# Altium schematic sheet Laser.SchDoc
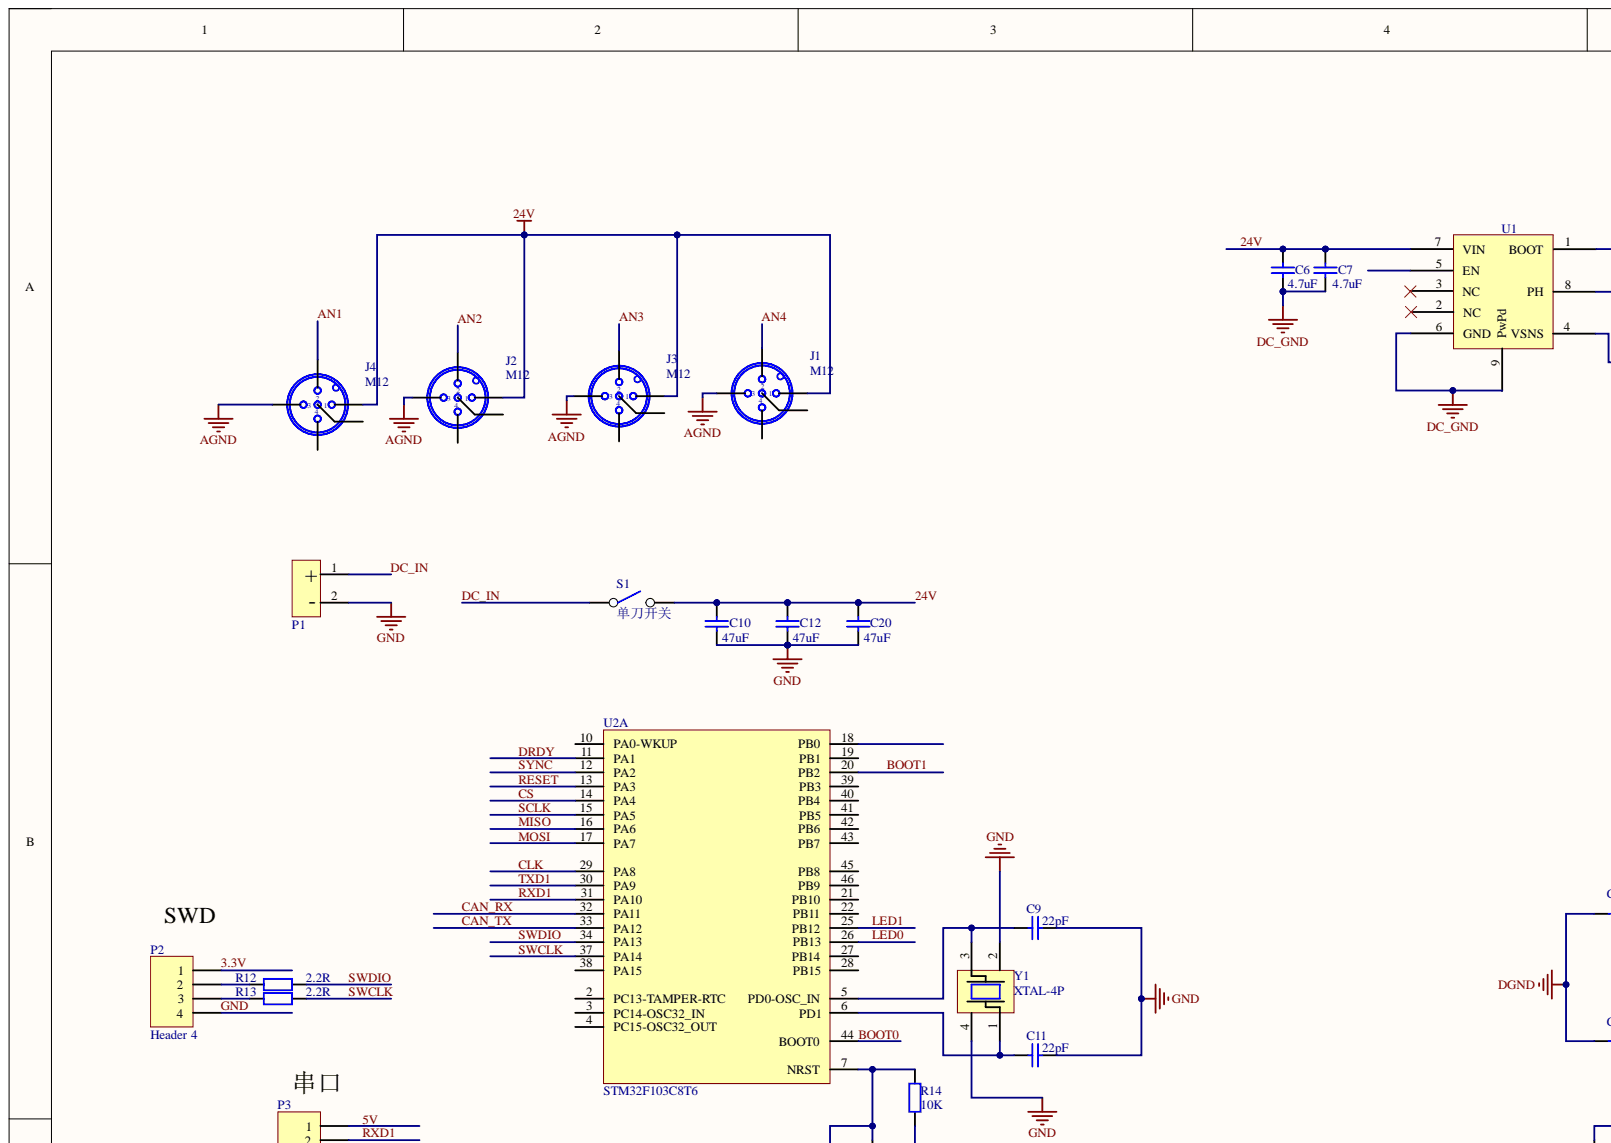
[diagram p0: Laser.SchDoc, top left]
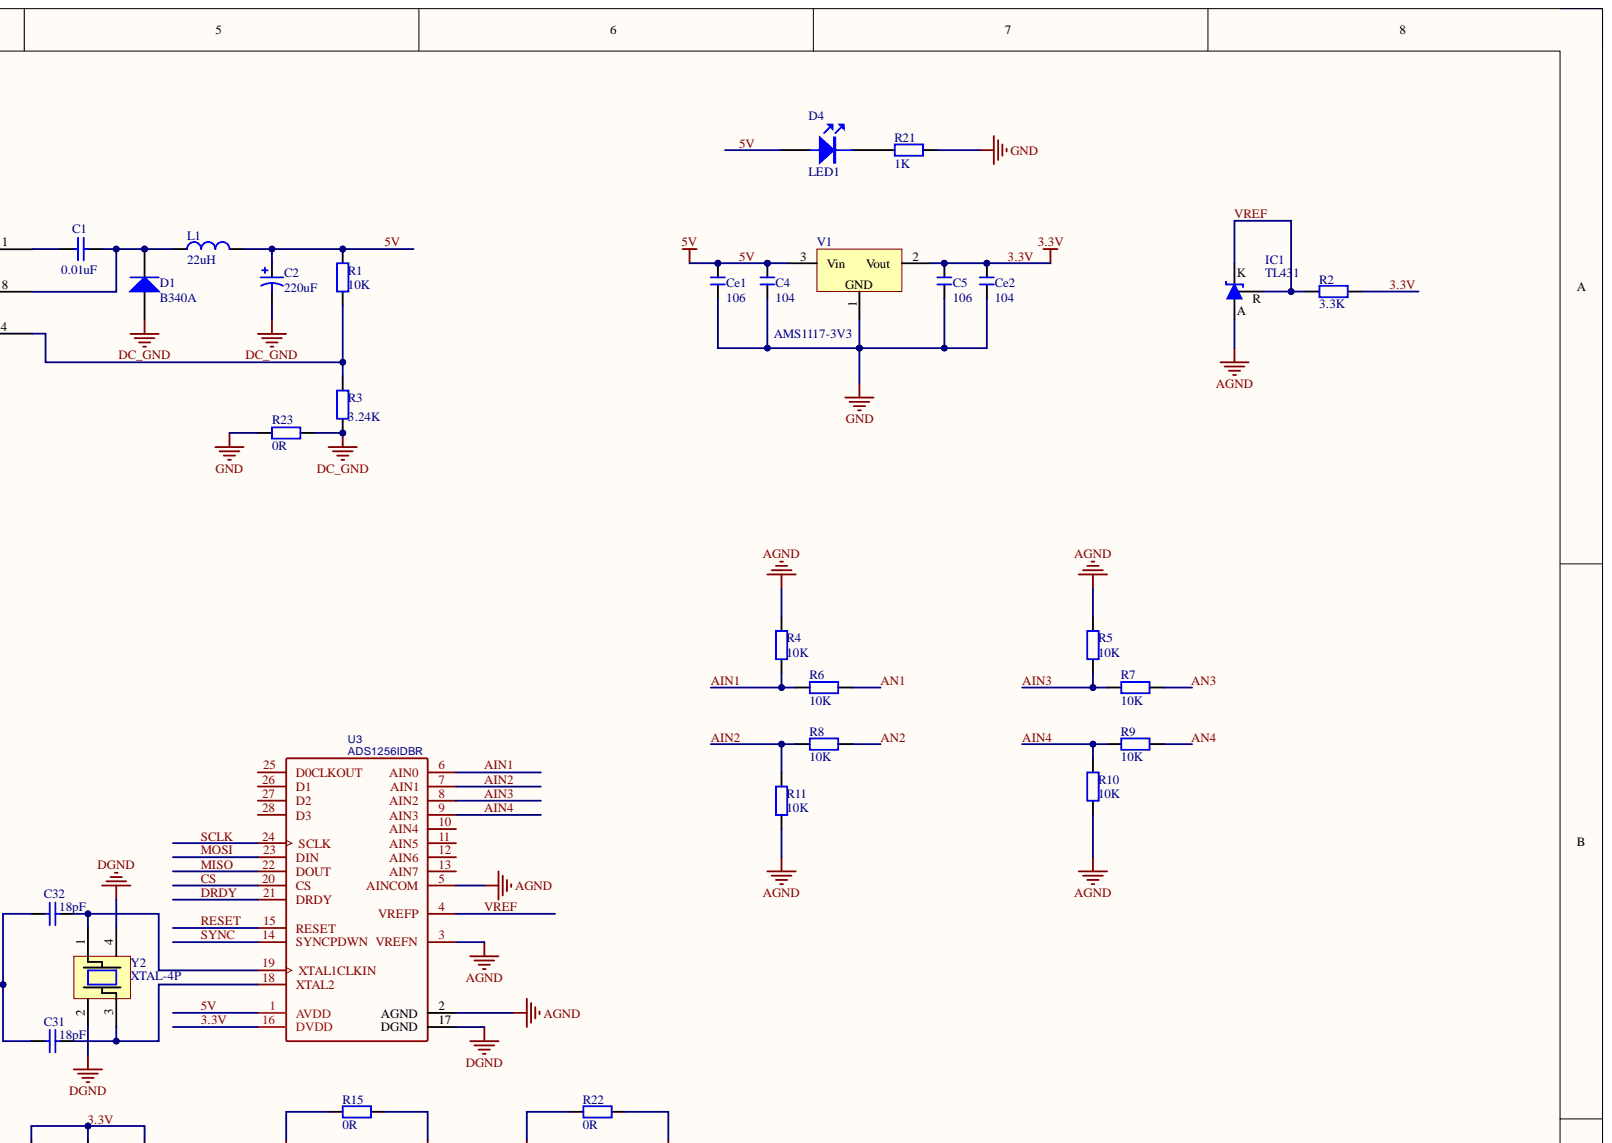
[diagram p1: Laser.SchDoc, top right]
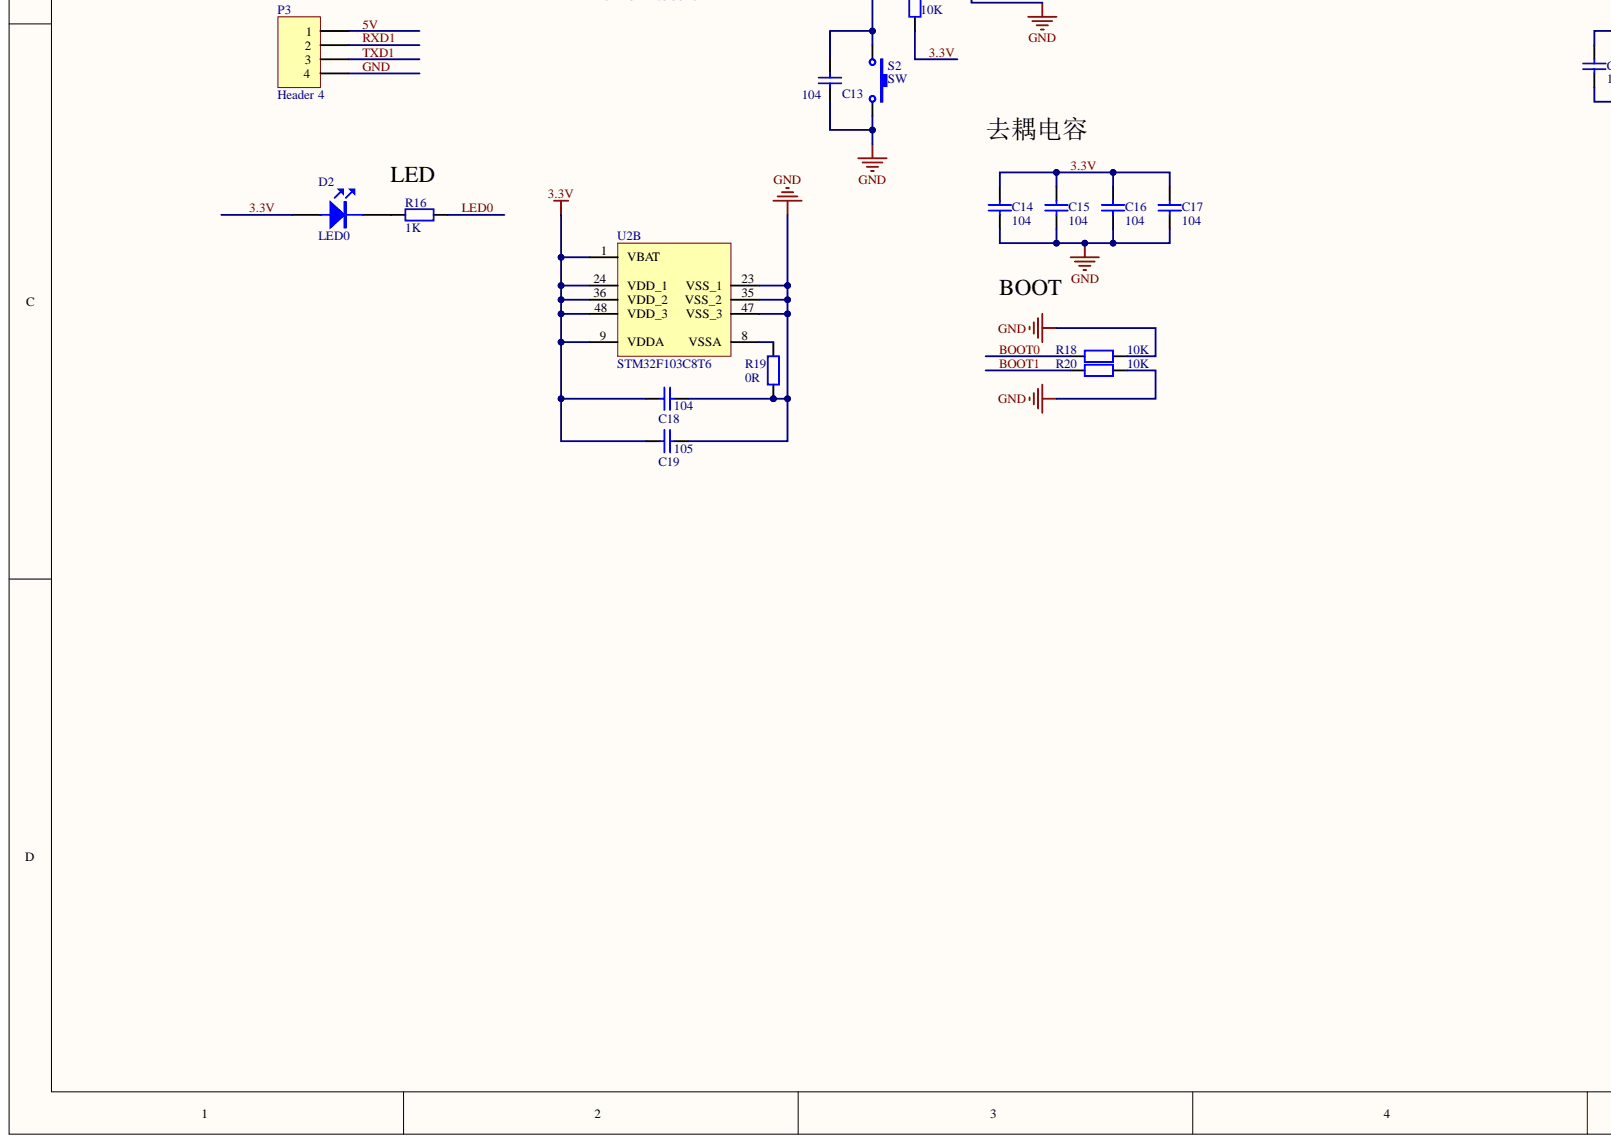
[diagram p2: Laser.SchDoc, bottom left]
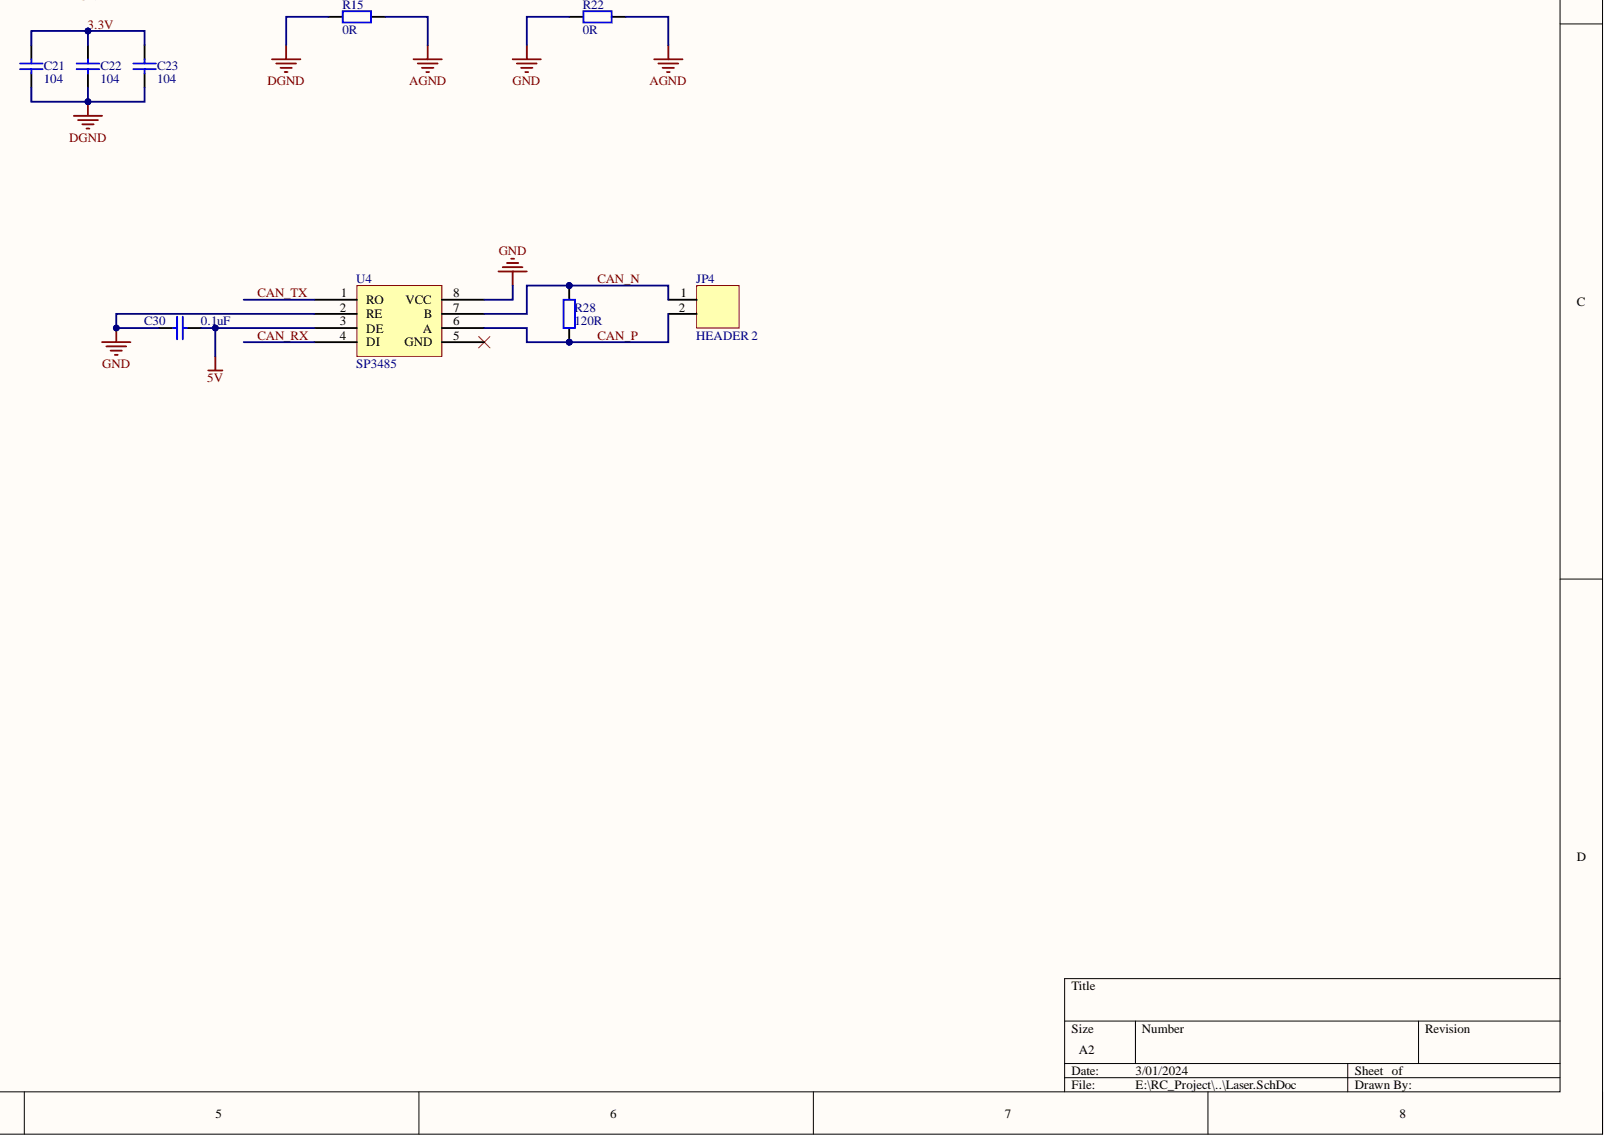
[diagram p3: Laser.SchDoc, bottom right]

## Parts
C1  Parameter 1: 0.01uF  [0805c]  (hidden comment: CAP-SMD)
C2  value 220uF  [CAP_VT 10*10.5]  (hidden comment: Cap Pol3)
C4  104  [0805c]
C5  106  [0805c]
C6  Parameter 1: 4.7uF  [0805c]  (hidden comment: CAP-SMD)
C7  Parameter 1: 4.7uF  [0805c]  (hidden comment: CAP-SMD)
C9  22pF  [0805c]
C10  47uF  [C1206]
C11  22pF  [0805c]
C12  47uF  [C1206]
C13  104  [0805c]
C14  104  [0805c]
C15  104  [0805c]
C16  104  [0805c]
C17  104  [0805c]
C18  104  [0805c]
C19  105  [0805c]
C20  47uF  [C1206]
C21  104  [0805c]
C22  104  [0805c]
C23  104  [0805c]
C30  0.1uF  [0805c]
C31  18pF  [0805c]
C32  18pF  [0805c]
Ce1  106  [0805c]
Ce2  104  [0805c]
D1  Type: B340A  [DIODE-SMA]  (hidden comment: Diode)
D2  LED0  [0805D]
D4  LED1  [0805D]
IC1  TL431  [SOT23-3N]
J1  M12  [M12]
J2  M12  [M12]
J3  M12  [M12]
J4  M12  [M12]
JP4  HEADER 2  [GH1.25-2P]
L1  value 22uH  [SMD-7/电感]  (hidden comment: Inductor)
P1  XT30PW-M  [XT30PW-M]  (value not drawn)
P2  Header 4  [GH1.25-4P]
P3  Header 4  [GH1.25-4P]
R1  Parameter 1: 10K  [R 0805]  (hidden comment: RES-SMD)
R2  Parameter 1: 3.3K  [R 0805]  (hidden comment: RES-SMD)
R3  Parameter 1: 3.24K  [R 0805]  (hidden comment: RES-SMD)
R4  10K  [0805R]
R5  10K  [0805R]
R6  10K  [0805R]
R7  10K  [0805R]
R8  10K  [0805R]
R9  10K  [0805R]
R10  10K  [0805R]
R11  10K  [0805R]
R12  value 2.2R  [0805R]  (hidden comment: Res1)
R13  value 2.2R  [0805R]  (hidden comment: Res1)
R14  value 10K  [0805R]  (hidden comment: Res1)
R15  0R  [0805R]
R16  value 1K  [0805R]  (hidden comment: Res1)
R18  value 10K  [0805R]  (hidden comment: Res1)
R19  value 0R  [0805R]  (hidden comment: Res1)
R20  value 10K  [0805R]  (hidden comment: Res1)
R21  value 1K  [0805R]  (hidden comment: Res1)
R22  0R  [0805R]
R23  Parameter 1: 0R  [R 0805]  (hidden comment: RES-SMD)
R28  value 120R  [0805R]  (hidden comment: 0R)
S1  单刀开关  [SWITCH_A2]
S2  SW  [SW_PB2_A1]
U1  TPS5430  [SOIC-8_L5.0-W4.0-P1.27-LS6.0-BL-EP2.0]  (value not drawn)
U2  STM32F103C8T6  [LQFP48_N]  (parts A,B)
U3  ADS1256IDBR  [SSOP-28]
U4  SP3485  [SOP-8]
V1  AMS1117-3V3  [SOT223_L]
Y1  XTAL-4P  [OSC-SMD_4P-L5.0-W3.2-BL]
Y2  XTAL-4P  [OSC-SMD_4P-L5.0-W3.2-BL]

## Nets
AGND: IC1.3 J1.3 J2.3 J3.3 J4.3 R4.1 R5.1 R10.2 R11.2 R15.1 R22.1 U3.2(AGND) U3.3(VREFN) U3.5(AINCOM)
DC_GND: C2.2 C6.1 C7.1 D1.1 R3.1 R23.1 U1.6(GND) U1.9(PwPd)
DGND: C21.1 C22.1 C23.1 C31.1 C32.1 R15.2 U3.17(DGND) Y2.2 Y2.4
3.3V: C5.2 C14.2 C15.2 C16.2 C17.2 C18.1 C19.1 C21.2 C22.2 C23.2 Ce2.2 D2.1 P2.1 R2.1 R14.2 U2.1(VBAT) U2.9(VDDA) U2.24(VDD_1) U2.36(VDD_2) U2.48(VDD_3) U3.16(DVDD) V1.2
5V: C2.1 C4.2 C30.1 Ce1.2 D4.1 L1.2 P3.1 R1.2 U3.1(AVDD) U4.3(DE) V1.3
24V: C6.2 C7.2 C10.2 C12.2 C20.2 J1.1 J2.1 J3.1 J4.1 S1.2 U1.7(VIN)
AIN1: R4.2 R6.1 U3.6(AIN0)
AIN2: R8.1 R11.1 U3.7(AIN1)
AIN3: R5.2 R7.1 U3.8(AIN2)
AIN4: R9.1 R10.1 U3.9(AIN3)
AN1: J4.2 R6.2
AN2: J2.2 R8.2
AN3: J3.2 R7.2
AN4: J1.2 R9.2
BOOT0: R18.1 U2.44(BOOT0)
BOOT1: R20.2 U2.20(PB2)
CAN_N: JP4.1 R28.1 U4.7(B)
CAN_P: JP4.2 R28.2 U4.6(A)
CAN_RX: U2.32(PA11) U4.4(DI)
CAN_TX: U2.33(PA12) U4.1(RO)
CLK: U2.29(PA8)
CS: U2.14(PA4) U3.20(CS)
DC_IN: P1.1 S1.1
DRDY: U2.11(PA1) U3.21(DRDY)
GND: C4.1 C5.1 C9.2 C10.1 C11.2 C12.1 C13.2 C14.1 C15.1 C16.1 C17.1 C18.2 C19.2 C20.1 C30.2 Ce1.1 Ce2.1 P1.2 P2.4 P3.4 R18.2 R19.1 R20.1 R21.2 R22.2 R23.2 S2.2 U2.23(VSS_1) U2.35(VSS_2) U2.47(VSS_3) U4.2(RE) U4.8(VCC) V1.1 Y1.2 Y1.4
LED0: R16.2 U2.26(PB13)
LED1: U2.25(PB12)
MISO: U2.16(PA6) U3.22(DOUT)
MOSI: U2.17(PA7) U3.23(DIN)
RESET: U2.13(PA3) U3.15(RESET)
RXD1: P3.2 U2.31(PA10)
SCLK: U2.15(PA5) U3.24(SCLK)
SWCLK: R13.2 U2.37(PA14)
SWDIO: R12.2 U2.34(PA13)
SYNC: U2.12(PA2) U3.14(SYNCPDWN)
TXD1: P3.3 U2.30(PA9)
VREF: IC1.1 IC1.2 R2.2 U3.4(VREFP)
NetC1_1: C1.1 D1.2 L1.1 U1.8(PH)
NetC1_2: C1.2 U1.1(BOOT)
NetC9_1: C9.1 U2.5(PD0-OSC_IN) Y1.3
NetC11_1: C11.1 U2.6(PD1) Y1.1
NetC13_1: C13.1 R14.1 S2.1 U2.7(NRST)
NetC31_2: C31.2 U3.18(XTAL2) Y2.3
NetC32_2: C32.2 U3.19(XTAL1CLKIN) Y2.1
NetD2_2: D2.2 R16.1
NetD4_2: D4.2 R21.1
NetP2_2: P2.2 R12.1
NetP2_3: P2.3 R13.1
NetR1_1: R1.1 R3.2 U1.4(VSNS)
NetR19_2: R19.2 U2.8(VSSA)

Not connected: J1 4(4_5) 5; J2 4(4_5) 5; J3 4 5; J4 4(4_5) 5; U1 2(NC) 3(NC) 5(EN); U2 2(PC13-TAMPER-RTC) 3(PC14-OSC32_IN) 4(PC15-OSC32_OUT) 10(PA0-WKUP) 18(PB0) 19(PB1) 21(PB10) 22(PB11) 27(PB14) 28(PB15) 38(PA15) 39(PB3) 40(PB4) 41(PB5) 42(PB6) 43(PB7) 45(PB8) 46(PB9); U3 10(AIN4) 11(AIN5) 12(AIN6) 13(AIN7) 25(D0CLKOUT) 26(D1) 27(D2) 28(D3); U4 5(GND)

## Library data of the parts
C1 C6 C7 C10 C12 C20 = CAP-SMD: 无极性贴片电容; Component Kind: Standard; Library Name: Ditaya.SchLib
  C10 C12 C20: Parameter 1: 47uF
C2 = Cap Pol3: Polarized Capacitor (Surface Mount); Component Kind: Standard; LatestRevisionDate: 29-May-2009; LatestRevisionNote: IPC-7351 Footprint Added.; Library Name: Miscellaneous Devices.IntLib; PackageDescription: Chip Capacitor; PackageReference: C0805; Published: 8-Jun-2000; Signal Integrity: Cap Pol; Simulation: CAP; Publisher: Altium Limited
C4 C5 Ce1 Ce2 = C: 无极性贴片电容; 版权归属: 源创客; BOM名称: 无极性贴片电容; Component Kind: Standard; Library Name: 3.1   - 电阻-电容-电感.SchLib; 学习资源: www.ooocke.com
C9 C11 C13-C19 C21-C23 C30-C32 = Capsitor: 无极性电容; Component Kind: Standard; LatestRevisionDate: 17-Jul-2002; LatestRevisionNote: Re-released for DXP Platform.; Library Name: RcLibrary_Sch.SchLib; PackageReference: RB7.6-15; Published: 8-Jun-2000; Publisher: Altium Limited
D1 = Diode_1: 二极管; Code_JEDEC: DO-214-AB; Component Kind: Standard; LatestRevisionDate: 17-Jul-2002; LatestRevisionNote: Re-released for DXP Platform.; Library Name: RcLibrary_Sch.SchLib; PackageDocument: Sep-1996; PackageReference: SMC; Published: 8-Jun-2000; Publisher: Altium Limited
D2 D4 = LED: Component Kind: Standard; Library Name: RcLibrary_Sch.SchLib
IC1 = TL431_SMD: 可调基准稳压芯片; 版权归属: 源创客; BOM名称: 可调基准稳压芯片; Component Kind: Standard; Library Name: 1.3   - 电源类芯片.SchLib; 学习资源: www.ooocke.com
L1 = Inductor: 电感; Code_IEC: 1005; Code_JEITA: 0402; Component Kind: Standard; LatestRevisionDate: 17-Jul-2002; LatestRevisionNote: Re-released for DXP Platform.; Library Name: RcLibrary_Sch.SchLib; PackageReference: 0402-A; Published: 8-Jun-2000; Publisher: Altium Limited
P2 P3 = Header 4: Header, 4-Pin; Component Kind: Standard; LatestRevisionDate: 17-Jul-2002; LatestRevisionNote: Re-released for DXP Platform.; Library Name: RcLibrary_Sch.SchLib; Signal Integrity: Connector; Publisher: Altium Limited
R1-R3 R23 = RES-SMD: 贴片电阻; Component Kind: Standard; Library Name: Ditaya.SchLib
R4-R16 R18-R22 R28 = Resistor/贴边&直插: 电阻; Component Kind: Standard; LatestRevisionDate: 17-Jul-2002; LatestRevisionNote: Re-released for DXP Platform.; Library Name: RcLibrary_Sch.SchLib; PackageReference: AXIAL-0.4; Published: 8-Jun-2000; Publisher: Altium Limited
  R4-R11: Value: 10K
  R15 R22: Value: 0R
S2 = SW/两引脚按键: 微动开关; Component Kind: Standard; LatestRevisionDate: 17-Jul-2002; LatestRevisionNote: Re-released for DXP Platform.; Library Name: RcLibrary_Sch.SchLib; PackageReference: SPST-2; Published: 24-Mar-1999; Publisher: Altium Limited
U1 = TPS5430: TI3A稳压模块4350; Component Kind: Standard; Library Name: RcLibrary_Sch.SchLib
U2 = STM32F103C8T6: STM32 ARM-based 32-bit MCU Performance Line with 64 kB Flash, 20 kB Internal RAM, -40 to +85癈 Temperature, 48-Pin LQFP, Tray; Component Kind: Standard; ComponentLink1Description: Manufacturer URL; ComponentLink1URL: http://www.st.com/; ComponentLink2Description: STM32F103 Datasheet; ComponentLink2URL: http://www.st.com/internet/com/TECHNICAL_RESOURCES/TECHNICAL_LITERATURE/DATASHEET/CD00161566.pdf; ComponentLink3Description: STM32 Family Web Page; ComponentLink3URL: www.st.com/stm32; DatasheetVersion: rev13.0, Apr-2011; Library Name: RcLibrary_Sch.SchLib; PackageDescription: 48-Pin Low-Profile Quad Flat Pack, 7 x 7 mm Body, 0.5 mm Pitch (LQFP48); PackageReference: LQFP48; PackageVersion: rev13, Apr-2011
U3 = ADS1256IDBR: BOM_Manufacturer: TI; BOM_JLCPCB Part Class: Extended Part; BOM_Manufacturer Part: ADS1256IDBR; BOM_Supplier: LCSC; BOM_Supplier Part: C28186; Component Kind: Standard; spicePre: U; spiceSymbolName: ADS1256IDBR
V1 = AMS1117: 三端稳压芯片; BOM名称: 稳压芯片; 版权归属: 源创客; Component Kind: Standard; Library Name: 1.3   - 电源类芯片.SchLib; 学习资源: www.ooocke.com
Y1 Y2 = XTAL-4P: 晶振4p; Component Kind: Standard; Library Name: RcLibrary_Sch.SchLib
J1-J4 = M12: Component Kind: Standard; Library Name: ADS1251.SCHLIB
JP4 = HEADER 2: Component Kind: Standard; Library Name: 小电机板器件库.SchLib
P1 = XT30PW-M: Component Kind: Standard; Library Name: RcLibrary_Sch.SchLib
S1 = 单刀开关: Component Kind: Standard; Library Name: 开关.SCHLIB
U4 = SP3485: Component Kind: Standard; Library Name: 小电机板器件库.SchLib
